# Altium schematic sheet memory.SchDoc(memory)
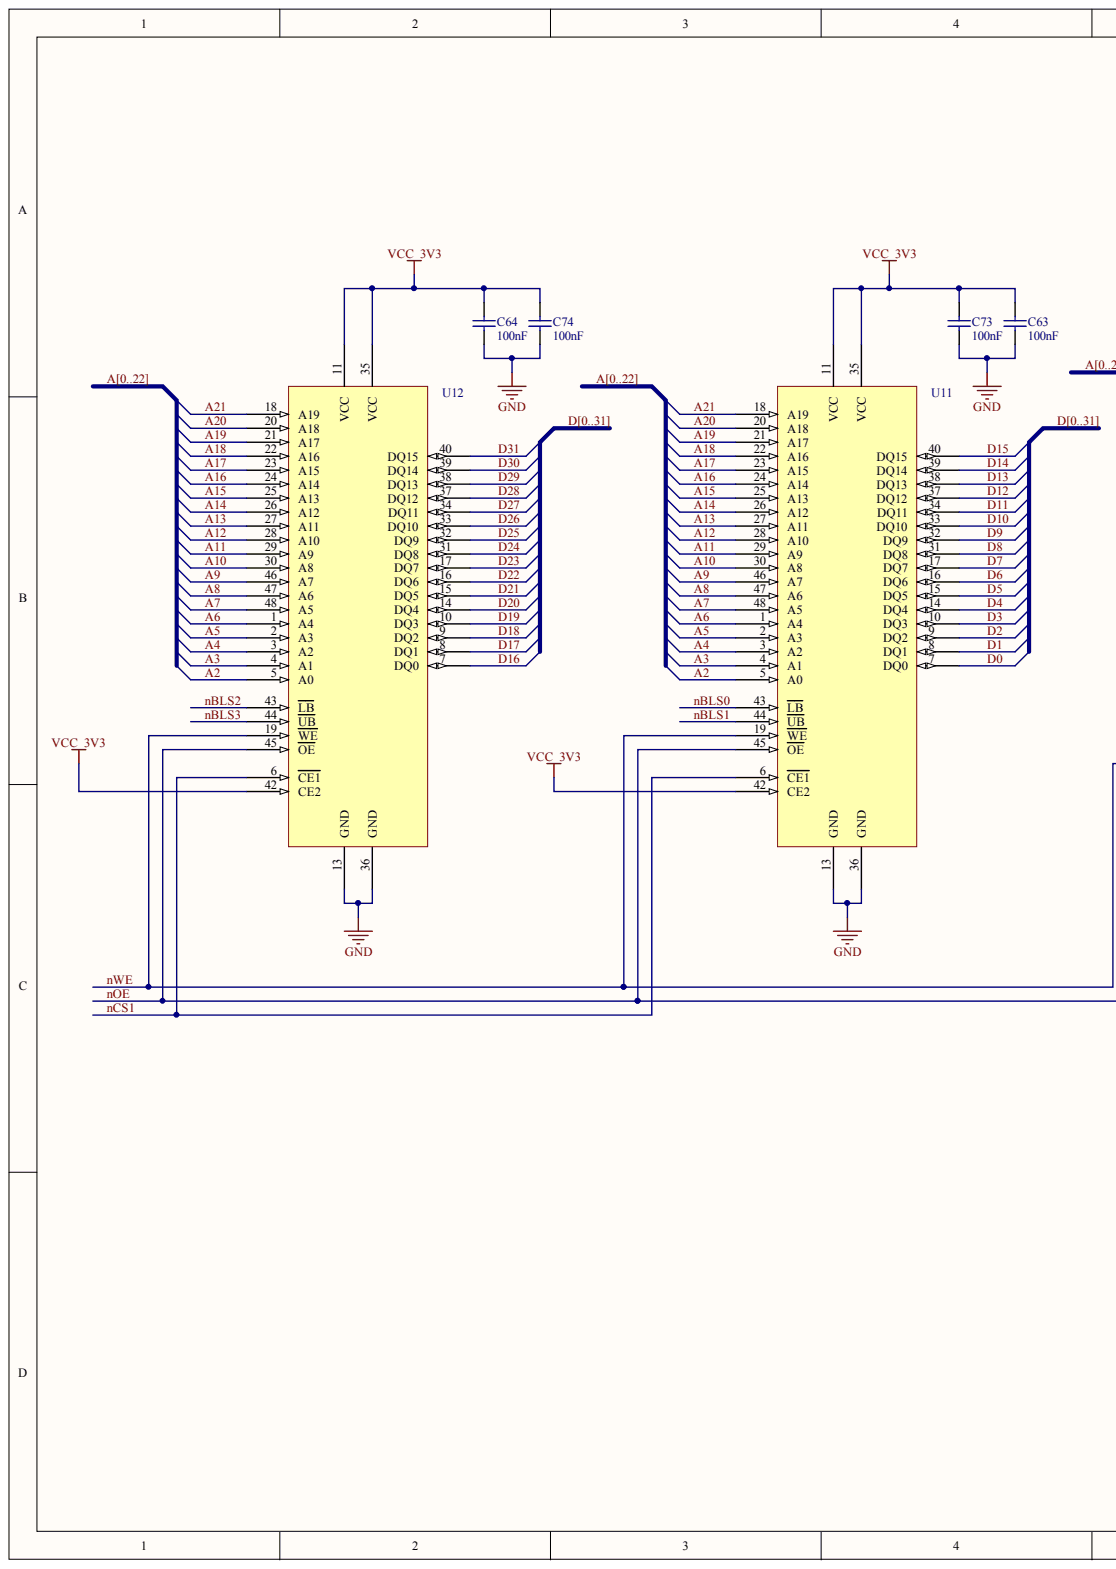
[diagram p0: memory.SchDoc, left]
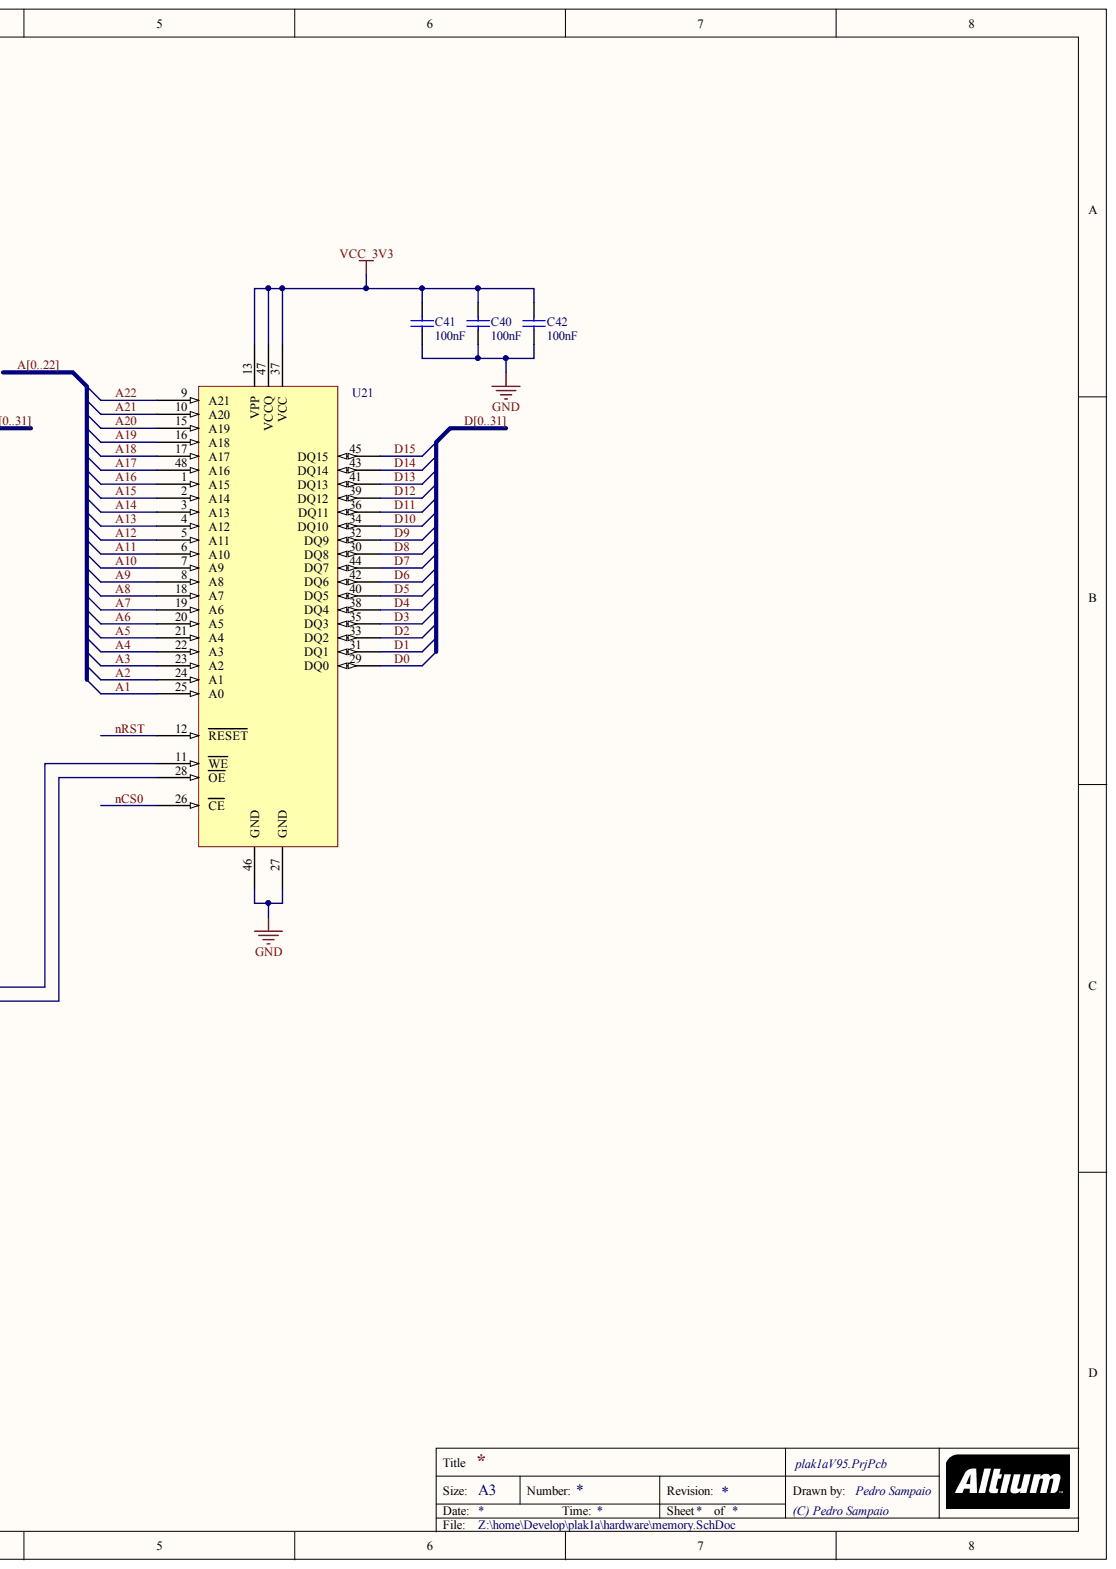
[diagram p1: memory.SchDoc, right]

## Parts
C40  value 100nF  [0603]  (hidden comment: C0603)
C41  value 100nF  [0603]  (hidden comment: C0603)
C42  value 100nF  [0603]  (hidden comment: C0603)
C63  value 100nF  [0603]  (hidden comment: C0603)
C64  value 100nF  [0603]  (hidden comment: C0603)
C73  value 100nF  [0603]  (hidden comment: C0603)
C74  value 100nF  [0603]  (hidden comment: C0603)
U11  BS616LV1611  [TSSOP50P2000-48AN]  (value not drawn)
U12  BS616LV1611  [TSSOP50P2000-48AN]  (value not drawn)
U21  AT49BV642D  [TSSOP50P2000-48AN]  (value not drawn)

## Nets
GND: C40.1 C41.1 C42.1 C63.1 C64.1 C73.1 C74.1 U11.13(GND) U11.36(GND) U12.13(GND) U12.36(GND) U21.27(GND) U21.46(GND)
VCC_3V3: C40.2 C41.2 C42.2 C63.2 C64.2 C73.2 C74.2 U11.11(VCC) U11.35(VCC) U11.42(CE2) U12.11(VCC) U12.35(VCC) U12.42(CE2) U21.13(VPP) U21.37(VCC) U21.47(VCCQ)
A1: U21.25(A0)  [also labelled A]
A2: U11.5(A0) U12.5(A0) U21.24(A1)  [also labelled A]
A3: U11.4(A1) U12.4(A1) U21.23(A2)  [also labelled A]
A4: U11.3(A2) U12.3(A2) U21.22(A3)  [also labelled A]
A5: U11.2(A3) U12.2(A3) U21.21(A4)  [also labelled A]
A6: U11.1(A4) U12.1(A4) U21.20(A5)  [also labelled A]
A7: U11.48(A5) U12.48(A5) U21.19(A6)  [also labelled A]
A8: U11.47(A6) U12.47(A6) U21.18(A7)  [also labelled A]
A9: U11.46(A7) U12.46(A7) U21.8(A8)  [also labelled A]
A10: U11.30(A8) U12.30(A8) U21.7(A9)  [also labelled A]
A11: U11.29(A9) U12.29(A9) U21.6(A10)  [also labelled A]
A12: U11.28(A10) U12.28(A10) U21.5(A11)  [also labelled A]
A13: U11.27(A11) U12.27(A11) U21.4(A12)  [also labelled A]
A14: U11.26(A12) U12.26(A12) U21.3(A13)  [also labelled A]
A15: U11.25(A13) U12.25(A13) U21.2(A14)  [also labelled A]
A16: U11.24(A14) U12.24(A14) U21.1(A15)  [also labelled A]
A17: U11.23(A15) U12.23(A15) U21.48(A16)  [also labelled A]
A18: U11.22(A16) U12.22(A16) U21.17(A17)  [also labelled A]
A19: U11.21(A17) U12.21(A17) U21.16(A18)  [also labelled A]
A20: U11.20(A18) U12.20(A18) U21.15(A19)  [also labelled A]
A21: U11.18(A19) U12.18(A19) U21.10(A20)  [also labelled A]
A22: U21.9(A21)  [also labelled A]
D0: U11.7(DQ0) U21.29(DQ0)  [also labelled D]
D1: U11.8(DQ1) U21.31(DQ1)  [also labelled D]
D2: U11.9(DQ2) U21.33(DQ2)  [also labelled D]
D3: U11.10(DQ3) U21.35(DQ3)  [also labelled D]
D4: U11.14(DQ4) U21.38(DQ4)  [also labelled D]
D5: U11.15(DQ5) U21.40(DQ5)  [also labelled D]
D6: U11.16(DQ6) U21.42(DQ6)  [also labelled D]
D7: U11.17(DQ7) U21.44(DQ7)  [also labelled D]
D8: U11.31(DQ8) U21.30(DQ8)  [also labelled D]
D9: U11.32(DQ9) U21.32(DQ9)  [also labelled D]
D10: U11.33(DQ10) U21.34(DQ10)  [also labelled D]
D11: U11.34(DQ11) U21.36(DQ11)  [also labelled D]
D12: U11.37(DQ12) U21.39(DQ12)  [also labelled D]
D13: U11.38(DQ13) U21.41(DQ13)  [also labelled D]
D14: U11.39(DQ14) U21.43(DQ14)  [also labelled D]
D15: U11.40(DQ15) U21.45(DQ15)  [also labelled D]
D16: U12.7(DQ0)  [also labelled D]
D17: U12.8(DQ1)  [also labelled D]
D18: U12.9(DQ2)  [also labelled D]
D19: U12.10(DQ3)  [also labelled D]
D20: U12.14(DQ4)  [also labelled D]
D21: U12.15(DQ5)  [also labelled D]
D22: U12.16(DQ6)  [also labelled D]
D23: U12.17(DQ7)  [also labelled D]
D24: U12.31(DQ8)  [also labelled D]
D25: U12.32(DQ9)  [also labelled D]
D26: U12.33(DQ10)  [also labelled D]
D27: U12.34(DQ11)  [also labelled D]
D28: U12.37(DQ12)  [also labelled D]
D29: U12.38(DQ13)  [also labelled D]
D30: U12.39(DQ14)  [also labelled D]
D31: U12.40(DQ15)  [also labelled D]
NBLS0: U11.43(LB)
NBLS1: U11.44(UB)
NBLS2: U12.43(LB)
NBLS3: U12.44(UB)
NCS0: U21.26(CE)
NCS1: U11.6(CE1) U12.6(CE1)
NOE: U11.45(OE) U12.45(OE) U21.28(OE)
NRST: U21.12(RESET)
NWE: U11.19(WE) U12.19(WE) U21.11(WE)
A0:  [also labelled A]

## Library data of the parts
C40-C42 C63 C64 C73 C74 = C0603: Ceramic Chip Capacitor - Standard; Note: Footprint corresponds to dimensions for bulk cassette packaging. This case size has tightened tolerances.; Code_IPC: 1608 [0603]; Code_JEITA: 0603; Component Kind: Standard; ComponentLink1Description: Manufacturer Link; ComponentLink1URL: http://www.kemet.com/; ComponentLink2Description: Datasheet; ComponentLink2URL: http://www.kemet.com/kemet/web/homepage/kechome.nsf/vapubfilesname/F3102TA.pdf/$file/F3102TA.pdf; DatasheetDocument: Mar-2001; Farnell: 1301716; LatestRevisionDate: 19-Mar-2004; LatestRevisionNote: Silkscreen size reduced.; Library Name: KEMET Chip Capacitor.IntLib; PackageDocument: Mar-2001; PackageReference: 0603; Published: 17-Jul-2002; Signal Integrity: C0603; Simulation: CAP; Publisher: Altium Limited
U11 U12 = BS616LV1611: Very Low Power CMOS SRAM 1M X 16 bit; PackageDocument: rev01, Oct-2004; Code_JEDEC: MO-077-AC; Component Kind: Standard; ComponentLink1Description: Manufacturer Link; ComponentLink1URL: http://www1.idt.com/pcms/products.taf?catID=58743&genID=71V124SA; ComponentLink2Description: Datasheet; ComponentLink2URL: http://www1.idt.com/pcms/tempDocs/71V124sa.pdf; DatasheetDocument: Jan-2004; Farnell: 1488926; Library Name: Componentes.IntLib; PackageReference: PJ32; Published: 6-Oct-2005; Publisher: Altium Limited
U21 = AT49BV642D: Very Low Power CMOS SRAM 1M X 16 bit; PackageDocument: rev01, Oct-2004; Code_JEDEC: MO-077-AC; Component Kind: Standard; ComponentLink1Description: Manufacturer Link; ComponentLink1URL: http://www1.idt.com/pcms/products.taf?catID=58743&genID=71V124SA; ComponentLink2Description: Datasheet; ComponentLink2URL: http://www1.idt.com/pcms/tempDocs/71V124sa.pdf; DatasheetDocument: Jan-2004; Farnell: 1455058; Library Name: Componentes.IntLib; PackageReference: PJ32; Published: 6-Oct-2005; Publisher: Altium Limited
